# Altium schematic sheet Debug IO Board.SchDoc("Debug IO Board")
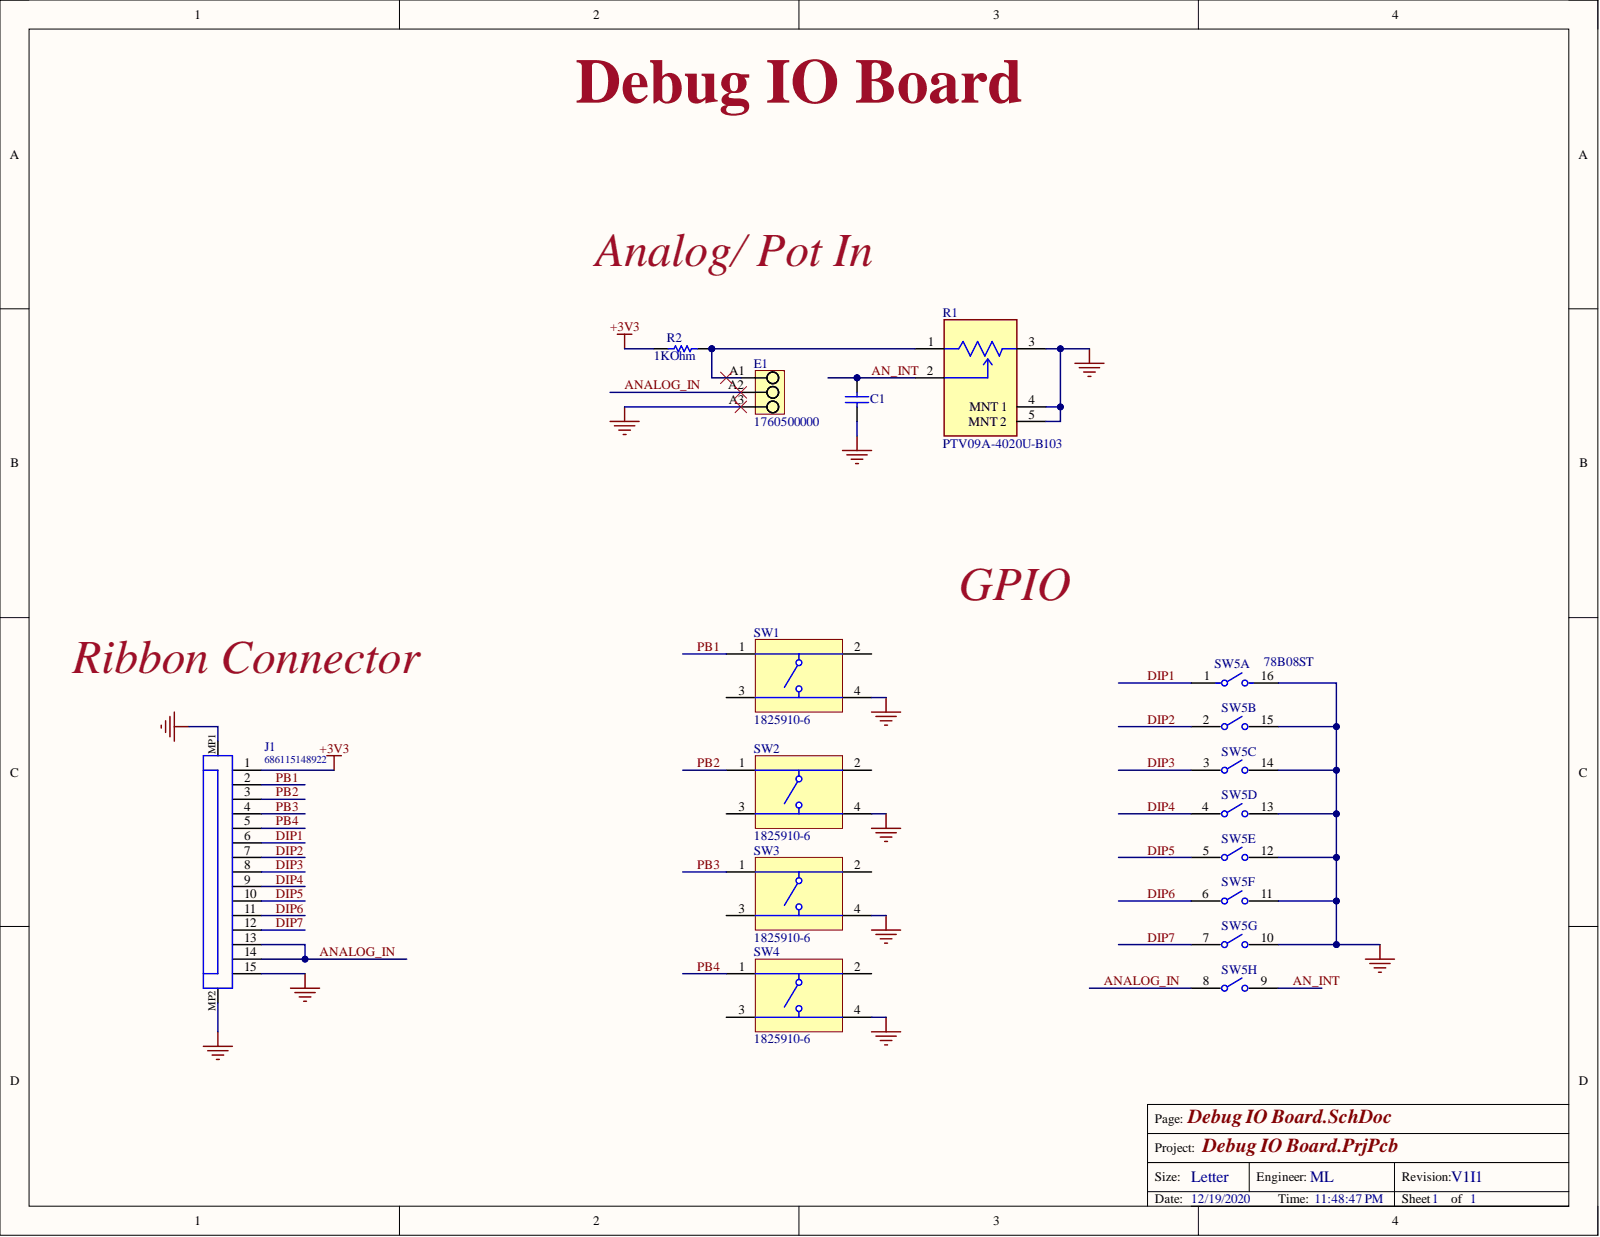
[diagram p0: Debug IO Board.SchDoc]

## Parts
C1  C0805C105K4PACTU  [CAPC2013X145X50LL20T25]  (value not drawn)
E1  1760500000  [PM_5_08_90_03]
J1  686115148922  [686115148922]
R1  PTV09A-4020U-B103  [FP-PTV09A-4-MFG]
R2  Resistance: 1KOhm  [RESC2013X60X35ML10T20]  (hidden comment: RC0805FR-071KL)
SW1  1825910-6  [TECO-1825910-6_V]
SW2  1825910-6  [TECO-1825910-6_V]
SW3  1825910-6  [TECO-1825910-6_V]
SW4  1825910-6  [TECO-1825910-6_V]
SW5  78B08ST  [FP-78B08ST-MFG]  (parts A,B,C,D,E,F,G,H)

## Nets
+3V3: J1.1 R2.1
GND: C1.1 E1.A3 J1.15 J1.MP1 J1.MP2 R1.3 R1.4(MNT_1) R1.5(MNT_2) SW1.4 SW2.4 SW3.4 SW4.4 SW5.10 SW5.11 SW5.12 SW5.13 SW5.14 SW5.15 SW5.16
AN_INT: C1.2 R1.2 SW5.9
ANALOG_IN: E1.A2 J1.13 J1.14 SW5.8
DIP1: J1.6 SW5.1
DIP2: J1.7 SW5.2
DIP3: J1.8 SW5.3
DIP4: J1.9 SW5.4
DIP5: J1.10 SW5.5
DIP6: J1.11 SW5.6
DIP7: J1.12 SW5.7
PB1: J1.2 SW1.1
PB2: J1.3 SW2.1
PB3: J1.4 SW3.1
PB4: J1.5 SW4.1
NetE1_A1: E1.A1 R1.1 R2.2

Not connected: SW1 2 3; SW2 2 3; SW3 2 3; SW4 2 3

## Library data of the parts
C1 = CMP-2007-01016-1: Manufacturer: Kemet; Component Kind: Standard; Library Name: Altium Content Vault; Part Number: C0805C105K4PACTU
E1 = CMP-1559-01629-1: OMNIMATE Signal - series PM; Manufacturer: Weidmueller; Contact_material: Cu-Leg; Contact_surface: tinned; Insulating_material: Wemid (PA); Mounting_onto_the_PCB: Solder connection; No_of_poles: 3; Pitch_inch: 0,2 inch; Pitch_mm: 5,08 mm; Rated_current_use_group_B_UL_1059: 15 A; Rated_voltage_for_surge_voltage_class_pollution_degree_II_2: 600 V; Rated_voltage_use_group_B_UL_1059: 300 V; Short_text_for_material: PM 5.08/03/90 3.5SN OR BX; Side_termination_characteristic: closed side; Solder_pin_length_mm: 3,5 mm; Solid_max_H05_07_V_U: 2,5 mm²; Solid_min_H05_07_V_U: 0,13 mm²; UL_94_flammability_rating: V-0; Wire_cross_section_AWG_max_UL_1059: AWG 14; Wire_cross_section_AWG_min_UL_1059: AWG 26; Colour: Orange; Component Kind: Standard; ComponentLink1Description: Manufacturer URL; ComponentLink1URL: http://www.weidmueller.com/; ComponentLink2Description: URL Link1; ComponentLink2URL: http://catalog.weidmueller.com/catalog/Start.do?localeId=en&ObjectID=1760500000; Library Name: Altium Content Vault; PackageReference: PM_5_08_90_03; Packaging: Box; PartNumber: 1760500000; Qty: 500 PCS; Revision: V1
J1 = CMP-1502-01518-1: SMT ZIF Connectors Hinge Type WR-FPC, Horizontal, Bottom Contact, pitch 1 mm, 15 pins; Manufacturer: Wurth Elektronik; Contact Resistance: 50mOhm; Pin Number: 15; Pitch: 1mm; Rated Current: 1A; Withstanding Voltage: 500VAC; Working Voltage: 100VAC; Component Kind: Standard; Contact Design: BottomContact; Datasheet URL: https://katalog.we-online.de/em/datasheet/686115148922.pdf; Library Name: Altium Content Vault; Manufacturer URL: http://www.we-online.de; Mounting Technology: SMT; Operating Temperature: -40to105degC; Orientation: Horizontal; Package Reference: 686115148922; Packaging: TapeandReel; Part Number: 686115148922; Publisher: WurthElektronik
R1 = CMP-07234-000013-1: POT 10K OHM 1/20W CARBON LINEAR; Manufacturer: Bourns Inc.; Power Rating: 0.05W; Resistance: 10kΩ; Tolerance: 20%; Value: 10kΩ; Voltage Rating: 50V; Automotive: No; Category: Res; Component Kind: Standard; ComponentLink2Description: Datasheet; ComponentLink2URL: https://www.bourns.com/docs/product-datasheets/ptv09.pdf?sfvrsn=5e1089f1_12; Composition: Carbon; Device Class L1: Passive Components; Device Class L2: Resistors; Device Class L3: Variable Resistors and Potentiometers; Digikey Description: POT 10K OHM 1/20W CARBON LINEAR; Height: 20.5mm; Lead Free: Yes; Library Name: Altium Content Vault; Manufacturer Part Number: PTV09A-4020U-B103; Mouser Description: Potentiometers 10K LINEAR 20%; Octopart: https://octopart.com/ptv09a-4020u-b103-bourns-1878033; Package: PTV09A-4; RoHS: TRUE; Series: PTV09; Temperature: -10°C to +50°C; Temperature Range High: +50°C; Temperature Range Low: -10°C
R2 = CMP-2100-03671-1: Chip Resistor, 1 KOhm, +/- 1%, 125 mW, -55 to 155 degC, 0805 (2012 Metric), RoHS, Tape and Reel; Manufacturer: Yageo; Max Power Dissipation: 125mW; Temperature Coefficient: 100ppm/degreesC; Voltage Rating: 150V; Case Code (Imperial): 0805; Case Code (Metric): 2012; Case/Package: 0805; Component Kind: Standard; Composition: ThickFilm; Datasheet URL: http://www.yageo.com/NewPortal/yageodocoutput?fileName=/pdf/R-Chip/PYu-RC_Group_51_RoHS_L_5.pdf; Datasheet Version: Rev. 5, 10/2016; Depth: 1.25mm; Height: 0.024inch; Lead Free: LeadFree; Length: 0.079inch; Library Name: Altium Content Vault; Manufacturer URL: http://www.yageo.com/; Max Operating Temperature: 155degC; Military Standard: Not; Min Operating Temperature: -55degC; Number of Pins: 2; Number of Terminations: 2; Package Description: 2-Pin Surface Mounted Device, Body 2 x 1.25 mm; Package Quantity: 1; Package Reference: 0805; Packaging: TapeandReel; Power Rating: 125mW; REACH SVHC: Unknown; RoHSCompliant: true; Termination: SMD/SMT; Tolerance: 1%; Voltage Rating (DC): 150V; Width: 0.049inch
SW1-SW4 = CMP-1684-00021-1: Tact Switch, SPST-NO, 0.05 A, -35 to 85 degC, 4-Pin THD, RoHS, Bulk; Manufacturer: TE Connectivity; Actuator Color: Black; Actuator Length: 1.4mm; Actuator Material: PolybutyleneTerephthalate; Actuator Style: RoundButton; Body Orientation: Straight; Bushing Type: Unthreaded; Contact Rating @ Voltage: 0.05A@24VDC; Dielectric Strength: 500VAC; Electrical Life: 100000Cycles; Function: MomentaryContact; Illumination: N/R; Maximum Contact Resistance: 100mOhm; Maximum DC Voltage Rating: 24V; Pole Throw Configuration: SPST; Switch Configuration: OFF(ON); Base Material: N/A; Component Kind: Standard; Contact Material: CopperAlloy; Contact Plating: Silver; Datasheet URL: http://www.te.com/commerce/DocumentDelivery/DDEController?Action=showdoc&DocId=Customer+Drawing%7F1825910%7FC9%7Fpdf%7FEnglish%7FENG_CD_1825910_C9.pdf%7F1825910-6; Datasheet Version: Rev. C9; Dimension: 5.99x5mm; Family: 1825910; Housing Material: N/A; Library Name: Altium Content Vault; Manufacturer URL: http://www.te.com; Maximum AC Voltage Rating: N/A; Mechanical Life: 100000Cycles; Minimum Insulation Resistance: 100MOhm; Mounting: ThroughHole; Operating Temperature: -35to85C; Package Description: 4-Pin Through Hole Device, Body 5.99 x 5.99 mm, Pitch 6.5 mm; Package Reference: THD; Packaging: N/A; Part No: 1825910-6; Product Weight: N/A; RoHS: Yes; Seal Description: N/A; Seal Location: N/A; Terminal Type: PCPins; Thread Size: N/R
SW5 = CMP-31942-000004-1: SWITCH SLIDE DIP SPST 150MA 30V; Manufacturer: Grayhill; Contact Resistance: 30mΩ; Electromechanical Life: 2000Cycles; Number Of Circuits: 8; Standoff Height: 0.46mm; Automotive: No; Category: Switch; Component Kind: Standard; ComponentLink2Description: Datasheet; ComponentLink2URL: http://www.grayhill.com/assets/1/7/DIP_Series_78_SPST-4PST.pdf#bookmark=id.nagx8c1orts0; Contact Current Rating: 150mA; Device Class L1: Electromechanical; Device Class L2: Switches; Device Class L3: DIP Switches; Digikey Description: SWITCH SLIDE DIP SPST 150MA 30V; Height: 8.05mm; Lead Free: Yes; Library Name: Altium Content Vault; Manufacturer Part Number: 78B08ST; Mount: Through Hole; Mouser Description: DIP Switches / SIP Switches DIP Switch SPST 8 Pos Tape Seal; Octopart: https://octopart.com/78b08st-grayhill-913939; Package: 78B08ST; RoHS: TRUE; Temperature: -40°C to +85°C; Temperature Range High: +85°C; Temperature Range Low: -40°C; Throw Configuration: SPST; Voltage Rating Dc: 30V
